# Altium schematic sheet Amp_schem.SchDoc
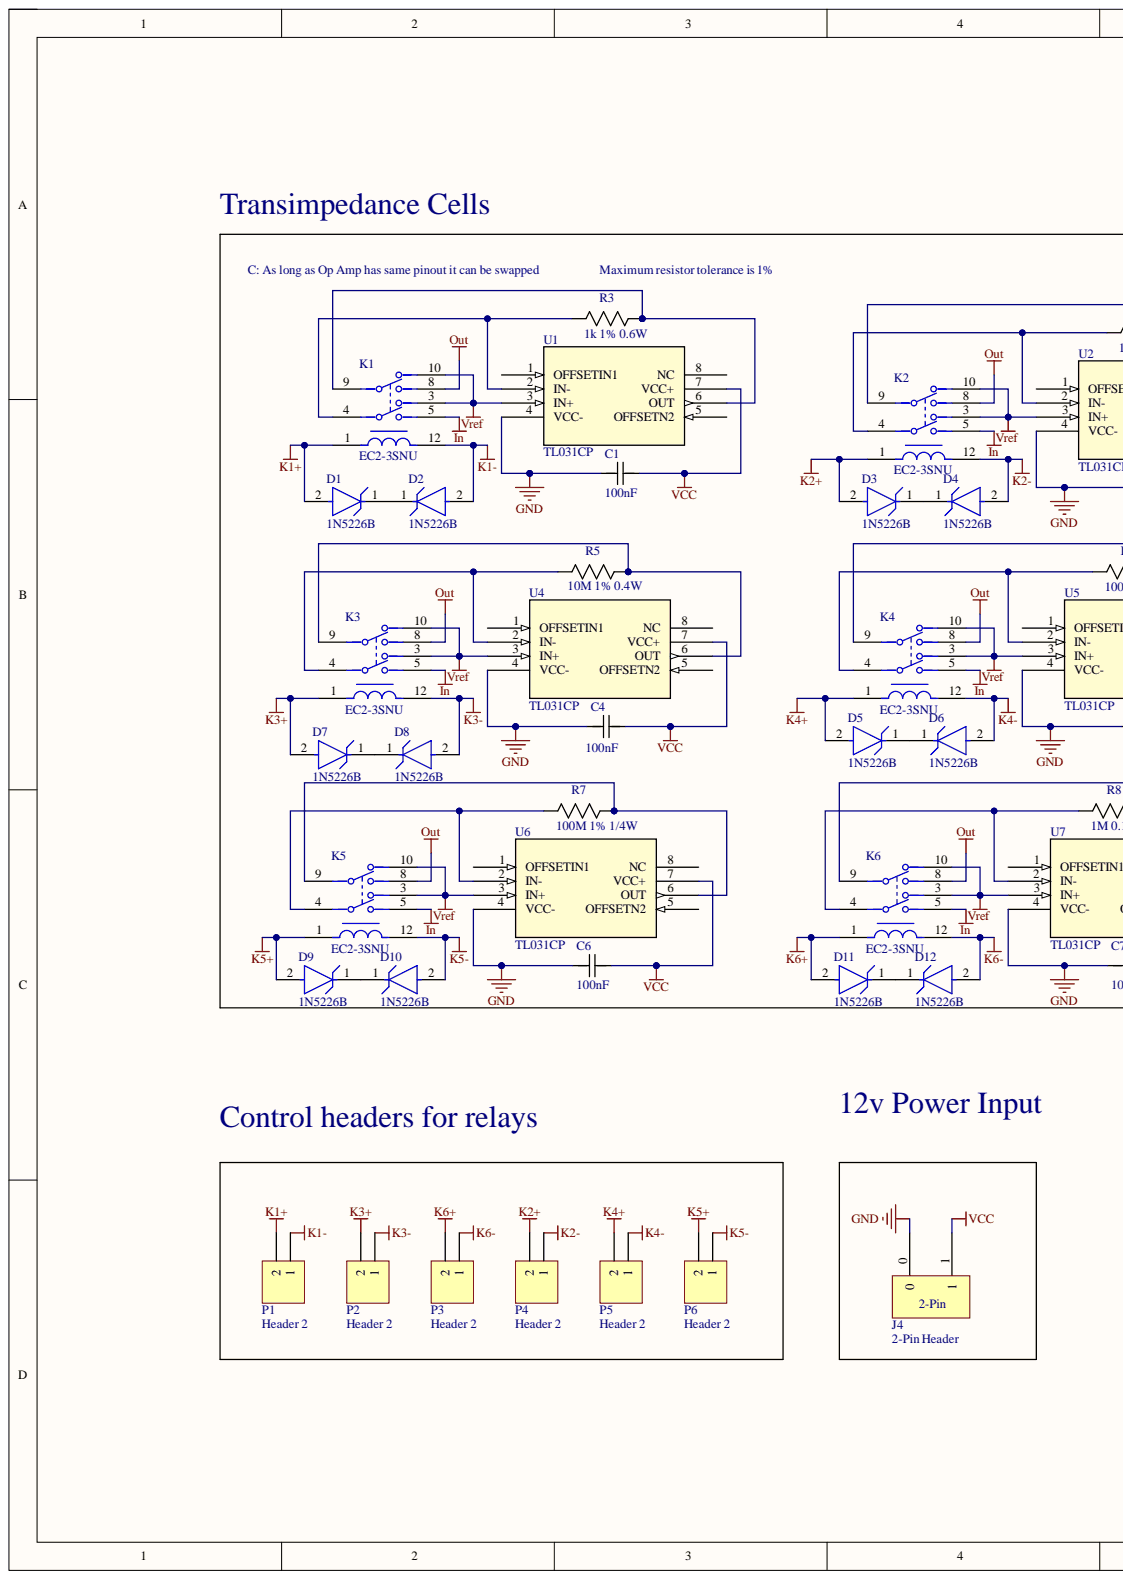
[diagram p0: Amp_schem.SchDoc, left]
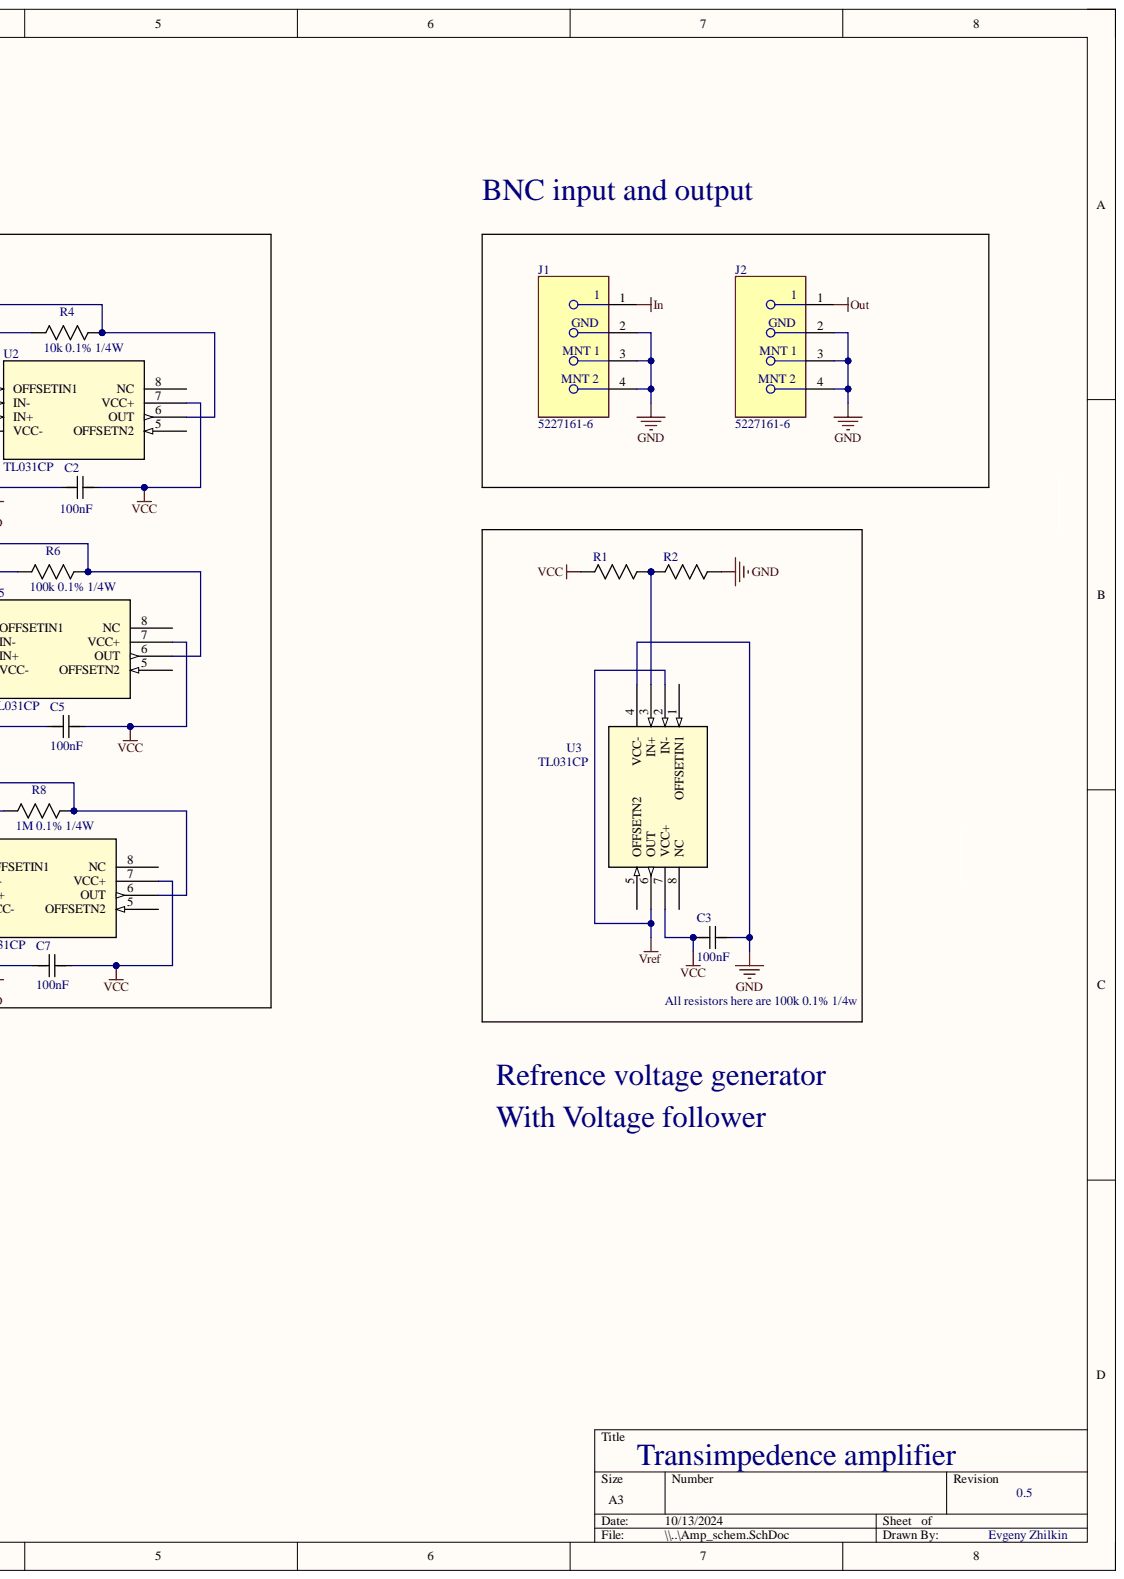
[diagram p1: Amp_schem.SchDoc, right]

## Parts
C1  100nF  [Resistor 1/4]
C2  100nF  [Resistor 1/4]
C3  100nF  [Resistor 1/4]
C4  100nF  [Resistor 1/4]
C5  100nF  [Resistor 1/4]
C6  100nF  [Resistor 1/4]
C7  100nF  [Resistor 1/4]
D1  1N5226B  [FP-017AG-MFG]
D2  1N5226B  [FP-017AG-MFG]
D3  1N5226B  [FP-017AG-MFG]
D4  1N5226B  [FP-017AG-MFG]
D5  1N5226B  [FP-017AG-MFG]
D6  1N5226B  [FP-017AG-MFG]
D7  1N5226B  [FP-017AG-MFG]
D8  1N5226B  [FP-017AG-MFG]
D9  1N5226B  [FP-017AG-MFG]
D10  1N5226B  [FP-017AG-MFG]
D11  1N5226B  [FP-017AG-MFG]
D12  1N5226B  [FP-017AG-MFG]
J1  5227161-6  [FP-5227161-6-MFG]
J2  5227161-6  [FP-5227161-6-MFG]
J4  2-Pin Header  [HDR1X2]
K1  EC2-3SNU  [Relay]
K2  EC2-3SNU  [Relay]
K3  EC2-3SNU  [Relay]
K4  EC2-3SNU  [Relay]
K5  EC2-3SNU  [Relay]
K6  EC2-3SNU  [Relay]
P1  Header 2  [HDR1X2]
P2  Header 2  [HDR1X2]
P3  Header 2  [HDR1X2]
P4  Header 2  [HDR1X2]
P5  Header 2  [HDR1X2]
P6  Header 2  [HDR1X2]
R1  100k 0.1% 1/4w  [Resistor 0.4W]
R2  100k 0.1% 1/4w  [Resistor 0.4W]
R3  1k 1% 0.6W  [Resistor 0.4W]
R4  10k 0.1% 1/4W  [Resistor 0.4W]
R5  10M 1% 0.4W  [Resistor 0.4W]
R6  100k 0.1% 1/4W  [Resistor 0.4W]
R7  100M 1% 1/4W  [Resistor 0.4W]
R8  1M 0.1% 1/4W  [Resistor 0.4W]
U1  TL031CP  [P8]
U2  TL031CP  [P8]
U3  TL031CP  [P8]
U4  TL031CP  [P8]
U5  TL031CP  [P8]
U6  TL031CP  [P8]
U7  TL031CP  [P8]

## Nets
GND: C1.1 C2.1 C3.2 C4.1 C5.1 C6.1 C7.1 J1.2 J1.3 J1.4 J2.2 J2.3 J2.4 J4.0 R2.2 U1.4(VCC-) U2.4(VCC-) U3.4(VCC-) U4.4(VCC-) U5.4(VCC-) U6.4(VCC-) U7.4(VCC-)
In: J1.1 K1.5 K2.5 K3.5 K4.5 K5.5 K6.5
K1+: D1.2 K1.1 P1.2
K1-: D2.2 K1.12 P1.1
K2+: D3.2 K2.1 P4.2
K2-: D4.2 K2.12 P4.1
K3+: D7.2 K3.1 P2.2
K3-: D8.2 K3.12 P2.1
K4+: D5.2 K4.1 P5.2
K4-: D6.2 K4.12 P5.1
K5+: D9.2 K5.1 P6.2
K5-: D10.2 K5.12 P6.1
K6+: D11.2 K6.1 P3.2
K6-: D12.2 K6.12 P3.1
Out: J2.1 K1.8 K2.8 K3.8 K4.8 K5.8 K6.8
VCC: C1.2 C2.2 C3.1 C4.2 C5.2 C6.2 C7.2 J4.1 R1.1 U1.7(VCC+) U2.7(VCC+) U3.7(VCC+) U4.7(VCC+) U5.7(VCC+) U6.7(VCC+) U7.7(VCC+)
Vref: K1.3 K1.10 K2.3 K2.10 K3.3 K3.10 K4.3 K4.10 K5.3 K5.10 K6.3 K6.10 U1.3(IN+) U2.3(IN+) U3.2(IN-) U3.6(OUT) U4.3(IN+) U5.3(IN+) U6.3(IN+) U7.3(IN+)
NetD1_1: D1.1 D2.1
NetD3_1: D3.1 D4.1
NetD5_1: D5.1 D6.1
NetD7_1: D7.1 D8.1
NetD9_1: D9.1 D10.1
NetD11_1: D11.1 D12.1
NetK1_4: K1.4 R3.1 U1.2(IN-)
NetK1_9: K1.9 R3.2 U1.6(OUT)
NetK2_4: K2.4 R4.1 U2.2(IN-)
NetK2_9: K2.9 R4.2 U2.6(OUT)
NetK3_4: K3.4 R5.1 U4.2(IN-)
NetK3_9: K3.9 R5.2 U4.6(OUT)
NetK4_4: K4.4 R6.1 U5.2(IN-)
NetK4_9: K4.9 R6.2 U5.6(OUT)
NetK5_4: K5.4 R7.1 U6.2(IN-)
NetK5_9: K5.9 R7.2 U6.6(OUT)
NetK6_4: K6.4 R8.1 U7.2(IN-)
NetK6_9: K6.9 R8.2 U7.6(OUT)
NetR1_2: R1.2 R2.1 U3.3(IN+)

Not connected: U1 1(OFFSETIN1) 5(OFFSETN2) 8(NC); U2 1(OFFSETIN1) 5(OFFSETN2) 8(NC); U3 1(OFFSETIN1) 5(OFFSETN2) 8(NC); U4 1(OFFSETIN1) 5(OFFSETN2) 8(NC); U5 1(OFFSETIN1) 5(OFFSETN2) 8(NC); U6 1(OFFSETIN1) 5(OFFSETN2) 8(NC); U7 1(OFFSETIN1) 5(OFFSETN2) 8(NC)

## Library data of the parts
C1-C7 = Cap: Component Kind: Standard; Library Name: TransAmpLibs.SchLib
D1-D12 = CMP-00278-02406619-1: 1N5226B 1N5226 Series 500 mW 3.3 V 200 mA Through Hole Zener Diode - DO-35; Manufacturer Lifecycle Status: ACTIVE; Breakdown Voltage: 3.3V; Element Configuration: Single; Forward Voltage: 1.2V; Impedance: 28Ω; Max Power Dissipation: 500mW; Max Reverse Leakage Current: 25µA; Test Current: 20mA; Tolerance: 5%; Component Kind: Standard; Height: 1.91mm; Lead Free: Lead Free; Length: 4.56mm; Library Name: Components - 12.10.24 - 21.30.SchLib; Lifecycle Status: Production; Max Operating Temperature: 200°C; Min Operating Temperature: -65°C; Mount: Through Hole; NexarPartId: 2406619; Number of Pins: 2; Packaging: Bulk; Peak Reverse Current: 25µA; Power Dissipation: 500mW; Power Rating: 500mW; Radiation Hardening: No; REACH SVHC: No SVHC; RoHS: Compliant; Schedule B: 8541100050, 8541100050|8541100050|8541100050|8541100050|8541100050; Voltage Rating (DC): 3.3V; Voltage Tolerance: 5%; Weight: 80g; Width: 1.91mm; Working Voltage: 3.3V; Zener Current: 20mA; Zener Voltage: 3.3V
J1 J2 = CMP-03790-39546762-1: 5227161-6 RF Connector, BNC, Jack, 50 Ohm, Right Angle PCB Mount, Commecial Grade; Manufacturer Lifecycle Status: ACTIVE; Body Material: Zinc; Body Plating: Nickel; Dielectric Material: Polymethylpentene; Frequency: 4GHz; Gender: Female; Impedance: 50Ω; Insulation Resistance: 5GΩ; Number of Contacts: 1; Outside Diameter: 14.529mm; Polarity: Normal, Standard; Sealable: No; Tail Length: 4.11mm; Voltage Rating: 500V; Color: Black; Component Kind: Standard; Connector Type: BNC; Contact Material: Gold; Contact Plating: Gold; Depth: 34.93mm; Fastening Type: Bayonet; Height: 16.13mm; Housing Color: Black; Housing Material: Polyester; Insulation Material: Polymethylpentene; Lead Free: Lead Free; Length: 34.93mm; Library Name: Components - 15.09.24 - 21.31.SchLib; Lifecycle Status: Production; Max Frequency: 4GHz; Max Operating Temperature: 85°C; Min Operating Temperature: -55°C; Mount: Panel; NexarPartId: 39546762; Number of Positions: 1; Orientation: Right Angle; Packaging: Bulk; PCB Mounting Orientation: Right Angle; Radiation Hardening: No; REACH SVHC: No SVHC; RoHS: Compliant; Termination: Solder, Through Hole
K1-K6 = Relay: Component Kind: Standard; Library Name: TransAmpLibs.SchLib; Supplier 1: Digikey; Supplier Part Number 1: 399-11043-5-ND
  K1: ComponentLink1Description: New link
P1-P6 = Header 2: Header, 2-Pin; Component Kind: Standard; LatestRevisionDate: 17-Jul-2002; LatestRevisionNote: Re-released for DXP Platform.; Library Name: Miscellaneous Connectors.IntLib; Signal Integrity: Connector; Publisher: Altium Limited
R1 R2 R6 = Resistor 1/4W: Mfr_Name: Vishay; Part_Name: MF1/4CCT52R1003B; Component Kind: Standard; Library Name: TransAmpLibs.SchLib; Supplier 1: Digikey; Supplier Part Number 1: 2019-MF1/4CCT52R1003BCT-ND
R3 = Resistor 1/4W: Mfr_Name: Vishay; Part_name: LR1F1K0; Component Kind: Standard; Library Name: TransAmpLibs.SchLib; Supplier 1: Digikey; Supplier Part Number 1: A105951CT-ND
R4 = Resistor 1/4W: Mfr_Name: Vishay; Part_name: MF1/4CCT52R1002B; Component Kind: Standard; Library Name: TransAmpLibs.SchLib; Supplier 1: Digikey; Supplier Part Number 1: 2019-MF1/4CCT52R1002BCT-ND
R5 = Resistor 1/4W: Mfr_Name: Vishay; Part_Name: MBA02040C1005FCT00; Component Kind: Standard; Library Name: TransAmpLibs.SchLib; Supplier 1: Digikey; Supplier Part Number 1: 56-MBA02040C1005FCT00CT-ND
R7 = Resistor 1/4W: Mfr_Name: Vishay; Part_Name: HVA05FA500M; Component Kind: Standard; Library Name: TransAmpLibs.SchLib; Supplier 1: Digikey; Supplier Part Number 1: HVA05FA500MCT-ND
R8 = Resistor 1/4W: Mfr_Name: Vishay; Part_Name: RN60C1004BRE6; Component Kind: Standard; Library Name: TransAmpLibs.SchLib; Supplier 1: Digikey; Supplier Part Number 1: 541-RN60C1004BRE6CT-ND
U1-U7 = TL031CP: No Description Available; Mfr_Name: Texas Instruments; Component Kind: Standard; Library Name: TL031CP.SchLib; RefDes: RefDes; Supplier 1: Digikey; Supplier Part Number 1: 296-7144-5-ND; Type: Type
J4 = 2-Pin Header: Component Kind: Standard; Library Name: TransAmpLibs.SchLib
